# Altium schematic sheet power.SchDoc(power)
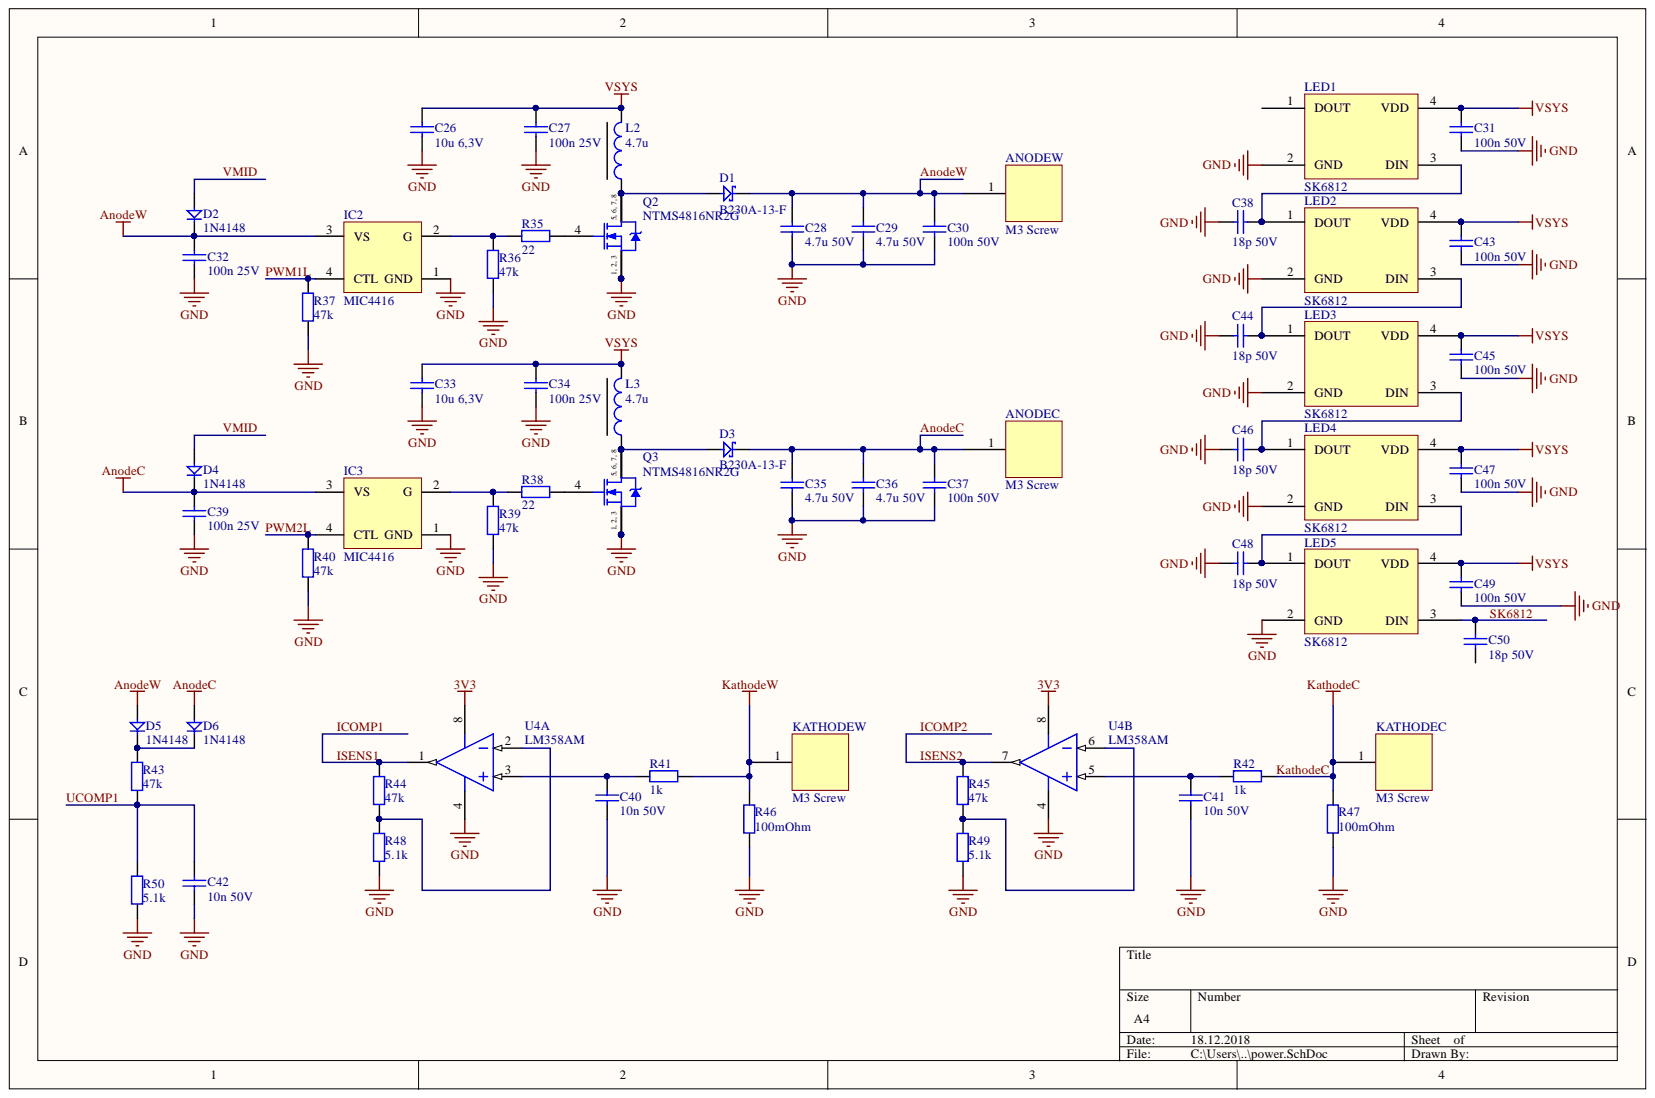
[diagram p0: power.SchDoc]

## Parts
ANODEC  M3 Screw  [M3 Screw]
ANODEW  M3 Screw  [M3 Screw]
C26  10u 6,3V  [C-0603-H]
C27  100n 25V  [C-0603-H]
C28  4.7u 50V  [C-1206-H]
C29  4.7u 50V  [C-1206-H]
C30  100n 50V  [C-0603-H]
C31  100n 50V  [C-0603-H]
C32  100n 25V  [C-0603-H]
C33  10u 6,3V  [C-0603-H]
C34  100n 25V  [C-0603-H]
C35  4.7u 50V  [C-1206-H]
C36  4.7u 50V  [C-1206-H]
C37  100n 50V  [C-0603-H]
C38  18p 50V  [C-0603-H]
C39  100n 25V  [C-0603-H]
C40  10n 50V  [C-0603-H]
C41  10n 50V  [C-0603-H]
C42  10n 50V  [C-0603-H]
C43  100n 50V  [C-0603-H]
C44  18p 50V  [C-0603-H]
C45  100n 50V  [C-0603-H]
C46  18p 50V  [C-0603-H]
C47  100n 50V  [C-0603-H]
C48  18p 50V  [C-0603-H]
C49  100n 50V  [C-0603-H]
C50  18p 50V  [C-0603-H]
D1  B230A-13-F  [DIODE-SMA]
D2  1N4148  [SOD323F]
D3  B230A-13-F  [DIODE-SMA]
D4  1N4148  [SOD323F]
D5  1N4148  [SOD323F]
D6  1N4148  [SOD323F]
IC2  MIC4416  [MIC4416]
IC3  MIC4416  [MIC4416]
KATHODEC  M3 Screw  [M3 Screw]
KATHODEW  M3 Screw  [M3 Screw]
L2  4.7u  [LPS4012]
L3  4.7u  [LPS4012]
LED1  SK6812  [SK6812]
LED2  SK6812  [SK6812]
LED3  SK6812  [SK6812]
LED4  SK6812  [SK6812]
LED5  SK6812  [SK6812]
Q2  NTMS4816NR2G  [ONSC-SOIC-8-751-07_V]
Q3  NTMS4816NR2G  [ONSC-SOIC-8-751-07_V]
R35  22  [R-0603-M]
R36  47k  [R-0603-H]
R37  47k  [R-0603-H]
R38  22  [R-0603-M]
R39  47k  [R-0603-H]
R40  47k  [R-0603-H]
R41  1k  [R-0603-M]
R42  1k  [R-0603-M]
R43  47k  [R-0603-H]
R44  47k  [R-0603-H]
R45  47k  [R-0603-H]
R46  100mOhm  [R-2512-M]
R47  100mOhm  [R-2512-M]
R48  5.1k  [R-0603-H]
R49  5.1k  [R-0603-H]
R50  5.1k  [R-0603-H]
U4  LM358AM  [D0008A_L]  (parts A,B)

## Nets
3V3: U4.8
GND: C26.1 C27.1 C28.1 C29.1 C30.1 C31.1 C32.1 C33.1 C34.1 C35.1 C36.1 C37.1 C38.2 C39.1 C40.1 C41.1 C42.1 C43.1 C44.2 C45.1 C46.2 C47.1 C48.2 C49.1 IC2.1(GND) IC3.1(GND) LED1.2(GND) LED2.2(GND) LED3.2(GND) LED4.2(GND) LED5.2(GND) Q2.1 Q2.2 Q2.3 Q3.1 Q3.2 Q3.3 R36.1 R37.1 R39.1 R40.1 R46.1 R47.1 R48.1 R49.1 R50.1 U4.4
KathodeW: KATHODEW.1 R41.1 R46.2
VSYS: C26.2 C27.2 C31.2 C33.2 C34.2 C43.2 C45.2 C47.2 C49.2 L2.2 L3.2 LED1.4(VDD) LED2.4(VDD) LED3.4(VDD) LED4.4(VDD) LED5.4(VDD)
AnodeC: ANODEC.1 C35.2 C36.2 C37.2 C39.2 D3.2 D4.2 D6.1 IC3.3(VS)
AnodeW: ANODEW.1 C28.2 C29.2 C30.2 C32.2 D1.2 D2.2 D5.1 IC2.3(VS)
ICOMP1: R44.2 U4.1
ICOMP2: R45.2 U4.7
KathodeC: KATHODEC.1 R42.1 R47.2
PWM1L: IC2.4(CTL) R37.2
PWM2L: IC3.4(CTL) R40.2
SK6812: C50.2 LED5.3(DIN)
UCOMP1: C42.2 R43.1 R50.2
VMID: D2.1 D4.1
NetC38_1: C38.1 LED1.3(DIN) LED2.1(DOUT)
NetC40_2: C40.2 R41.2 U4.3
NetC41_2: C41.2 R42.2 U4.5
NetC44_1: C44.1 LED2.3(DIN) LED3.1(DOUT)
NetC46_1: C46.1 LED3.3(DIN) LED4.1(DOUT)
NetC48_1: C48.1 LED4.3(DIN) LED5.1(DOUT)
NetD1_1: D1.1 L2.1 Q2.5 Q2.6 Q2.7 Q2.8
NetD3_1: D3.1 L3.1 Q3.5 Q3.6 Q3.7 Q3.8
NetD5_2: D5.2 D6.2 R43.2
NetIC2_2: IC2.2(G) R35.1 R36.2
NetIC3_2: IC3.2(G) R38.1 R39.2
NetQ2_4: Q2.4 R35.2
NetQ3_4: Q3.4 R38.2
NetR44_1: R44.1 R48.2 U4.2
NetR45_1: R45.1 R49.2 U4.6

Not connected: C50 1; LED1 1(DOUT)

## Library data of the parts
ANODEC ANODEW KATHODEC KATHODEW = M3: Library Name: M3.SchLib; Component Kind: Standard
C26 C33 = C-0603-10u-6,3V: Capacitor 10uF 6,3V; Supplier Part Number 1: 80-C0603C106M9P; Supplier 1: Mouser; Library Name: Passives.IntLib; Component Kind: Standard
C27 C32 C34 C39 = C-0603-100n-25V: Capacitor 100n 25V; Supplier Part Number 1: 80-C0603C104K3R; Supplier 1: Mouser; Library Name: Capacitors-0603.SchLib; Component Kind: Standard
C28 C29 C35 C36 = C-1206-4u7-50V: Capacitor 4.7uF 50V; Supplier Part Number 1: 81-GRM31CR71H475KA2L; Supplier 1: Mouser; Library Name: Capacitors-1206.SchLib; Component Kind: Standard
C30 C31 C37 C43 C45 C47 C49 = C-0603-100n-50V: Capacitor 100n 50V; Supplier Part Number 1: 80-C0603C104K5RAUTO; Supplier 1: Mouser; Library Name: Capacitors-0603.SchLib; Component Kind: Standard
C38 C44 C46 C48 C50 = C-0603-18p-50V: Capacitor 18pF 50V; Supplier Part Number 1: 80-C0603C180J5G; Supplier 1: Mouser; Library Name: Capacitors-0603.SchLib; Component Kind: Standard
C40-C42 = C-0603-10n-50V: Capacitor 10nF 50V; Supplier Part Number 1: 80-C0603C103K5RAC; Supplier 1: Mouser; Library Name: Passives.IntLib; Component Kind: Standard
D1 D3 = D-B230A-13-F: Supplier Part Number 1: 621-B230A-F; Supplier 1: Mouser; Library Name: advanced.SchLib; Description: B230A-13-F; Component Kind: Standard
D2 D4-D6 = 1N4148: Diode 1N4148; Supplier Part Number 1: 512-1N4148WS; Supplier 1: Mouser; Library Name: advanced.SchLib; Component Kind: Standard
IC2 IC3 = MIC4416: MIC4416 Low Side Mosfet gate driver IttyBitty; Library Name: advanced.SchLib; Component Kind: Standard
L2 L3 = L-4u7-LPS4012-472MRB: LPS4012-472MRB; Supplier Part Number 1: 994-LPS4012-472MRB; Supplier 1: Mouser; Library Name: Inductors.SchLib; Component Kind: Standard
Q2 Q3 = CMP-1058-00691-1: Power MOSFET, 30 V, 11 A, N-Channel, 8-Pin SOIC, Pb-Free, Tape and Reel; Manufacturer: On Semiconductor; VGS Max (V): 20; VGS(th) Max (V): 3; V(BR)DSS Min (V): 30; Qrr Typ (nC): 9; ID Max (A): 11; Crss Typ (pF): 126; Coss Typ (pF): 220; Configuration: Single; Ciss Typ (pF): 1060; Channel Polarity: N Channel; RoHS: Pb-Free; PartNumber: NTMS4816NR2G; Packing: Tape and Reel; PackageVersion: Rev. AK, 02/2011; Package Type: SOIC-8; PackageReference: SOIC-8-751-07; PackageDescription: 8-Pin Small Outline Integrated Circuit, Body 4.9 x 3.9 mm, Pitch 1.27 mm; Mounting Technology: Surface Mount; Library Name: Altium Content Vault; DatasheetVersion: Rev. 2; ComponentLink3URL: http://www.onsemi.com/pub_link/Collateral/751-07.PDF; ComponentLink3Description: Package Specification; ComponentLink2URL: http://www.onsemi.cn/pub_link/Collateral/NTMS4816N-D.PDF; ComponentLink2Description: Datasheet; ComponentLink1URL: http://www.onsemi.com/; ComponentLink1Description: Manufacturer URL; Component Kind: Standard
R35 R38 = R-0603-22: Resistor 22; Supplier Part Number 1: 71-CRCW0603-22-E3; Supplier 1: Mouser; Library Name: Resistors-0603.SchLib; Component Kind: Standard
R36 R37 R39 R40 R43-R45 = R-0603-47k: Resistor 4k7; Supplier Part Number 1: 71-CRCW060347K0FKEB; Supplier 1: Mouser; Library Name: Passives.IntLib; Component Kind: Standard
R41 R42 = R-0603-1k: Resistor 1k; Supplier Part Number 1: 71-CRCW0603-1.0K-E3; Supplier 1: Mouser; Library Name: Passives.IntLib; Component Kind: Standard
R46 R47 = R-2512-0.1: Resistor 0.1; Supplier 1: Mouser; Component Kind: Standard; Library Name: erleuchtung.IntLib
R48-R50 = R-0603-5k1: Resistor 5.1k; Supplier Part Number 1: 71-CRCW0603-5.1K-E3; Supplier 1: Mouser; Library Name: Passives.IntLib; Component Kind: Standard
U4 = CMP-0055-00796-3: Low Power Dual Operational Amplifier, 8-pin Narrow SOIC; Manufacturer: Texas Instruments; PowerWise: N; PackageTopMarking: NSZXTT*LM*358AM; CompType: Amplifiers; RoHS: N; PinCount: 8; Package Reference: D0008A; PackageDescription: SOIC Narrow, 4.9 x 3.9 x 1.45mm, 8 Lead, 1.27mm Pitch; Library Name: Altium Content Vault; ComponentLink1URL: http://www.ti.com/general/docs/lit/getliterature.tsp?genericPartNumber=LM358-N&fileType=pdf; ComponentLink1Description: Datasheet URL; Component Kind: Standard; Code_JEDEC: MS-012-AA; Code_IPC: SOIC127P600-8
LED1-LED5 = SK6812: Library Name: sk6812.SchLib; Description: SK6812; Component Kind: Standard
